# Altium schematic sheet Load.SchDoc
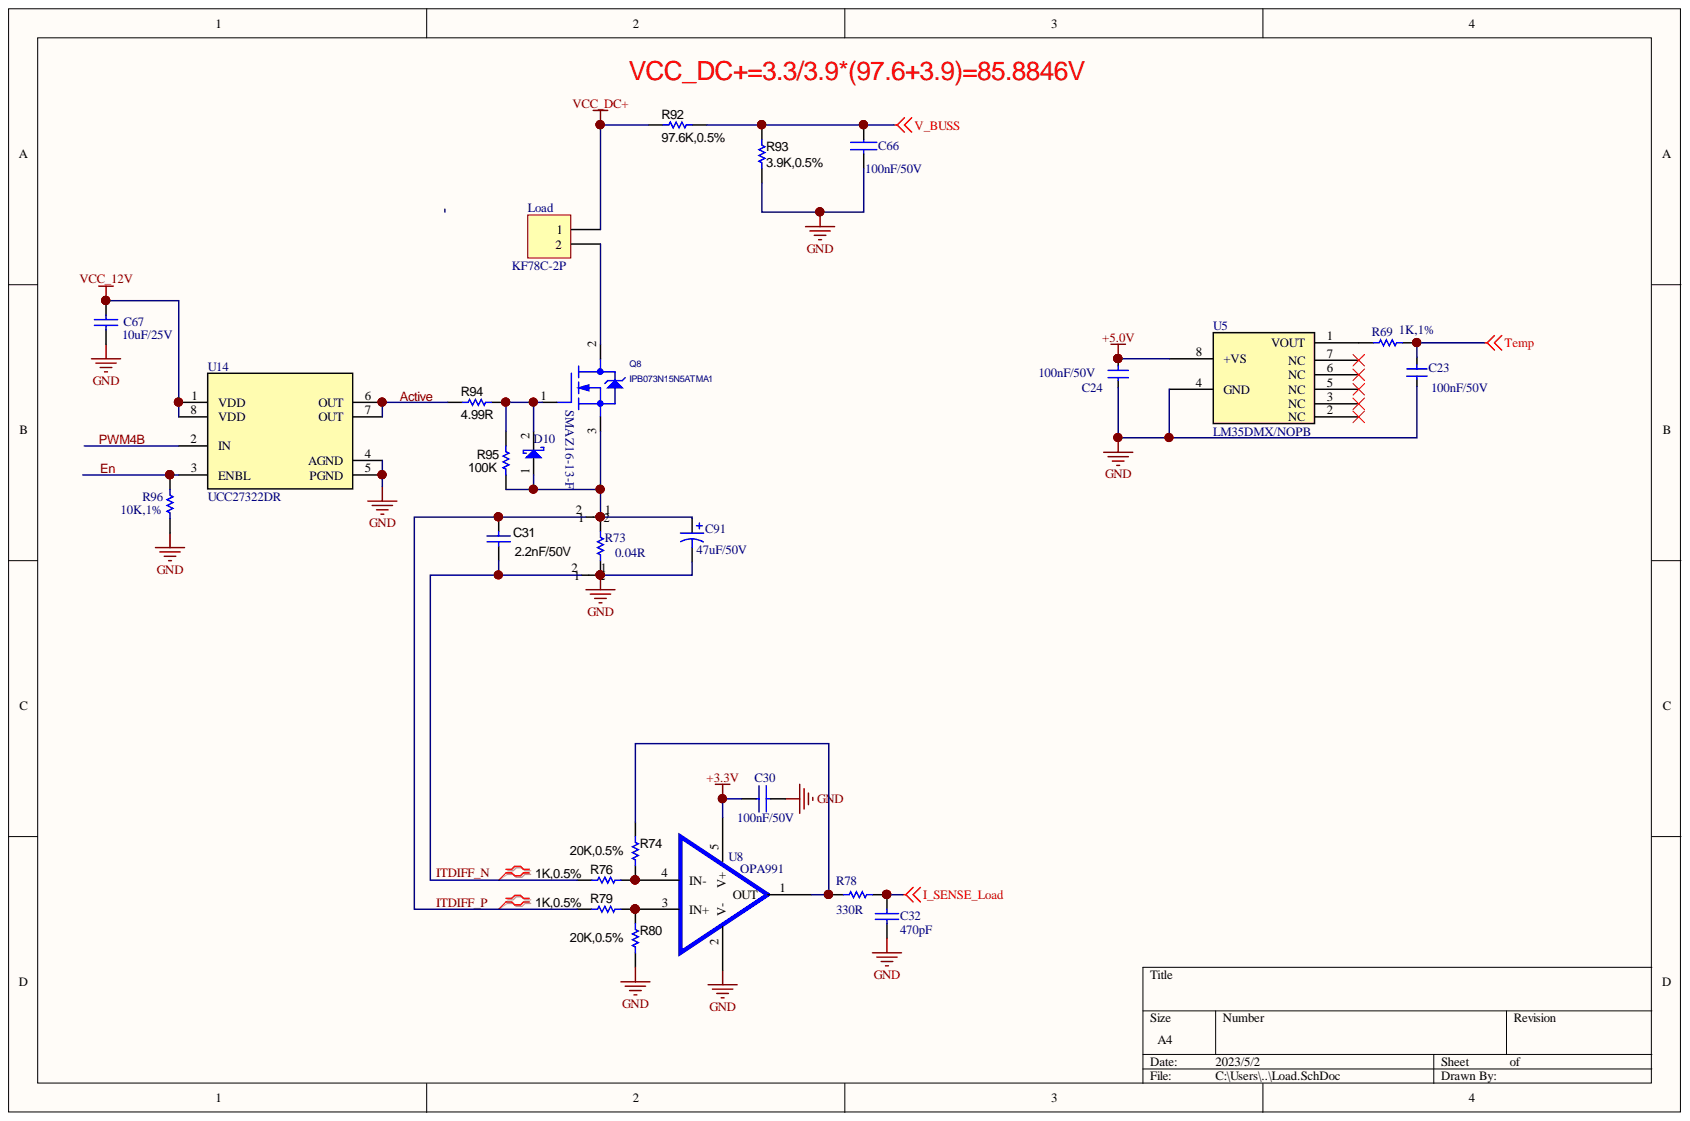
[diagram p0: Load.SchDoc]

## Parts
C23  100nF/50V  [0603]
C24  100nF/50V  [0603]
C30  100nF/50V  [0603]
C31  2.2nF/50V  [0603]
C32  470pF  [0603]
C66  100nF/50V  [0603]
C67  10uF/25V  [1206]
C91  47uF/50V  [HDR_1X2]
D10  SMAZ16-13-F  [Zener_SMA]
Load  KF78C-2P  [KF65C-2P]
NT7  NT  [diff_net_tie]  (value not drawn)
NT8  NT  [diff_net_tie]  (value not drawn)
Q8  IPB073N15N5ATMA1  [TO-263AB]
R69  1K,1%  [0603]
R73  0.04R  [4527]
R74  20K,0.5%  [0603]
R76  1K,0.5%  [0603]
R78  330R  [0603]
R79  1K,0.5%  [0603]
R80  20K,0.5%  [0603]
R92  97.6K,0.5%  [0603]
R93  3.9K,0.5%  [0603]
R94  4.99R  [0603]
R95  100K  [0603]
R96  10K,1%  [0603]
U5  LM35DMX/NOPB  [SOIC8_3.90MM]
U8  OPA991  [SOT-235]
U14  UCC27322DR  [SOIC8_3.90MM]

## Nets
+3.3V: C30.1 U8.5(V+)
+5.0V: C24.2 U5.8(+VS)
GND: C23.1 C24.1 C30.2 C32.2 C66.2 C67.1 C91.2 NT8.1 R73.2 R80.2 R93.1 R96.1 U5.4(GND) U8.2(V-) U14.4(AGND) U14.5(PGND)
I_SENSE_Load: C32.1 R78.1
Temp: C23.2 R69.1
V_BUSS: C66.1 R92.1 R93.2
VCC_12V: C67.2 U14.1(VDD) U14.8(VDD)
VCC_DC+: Load.1 R92.2
Active: R94.1 U14.6(OUT) U14.7(OUT)
En: R96.2 U14.3(ENBL)
ITDIFF_N: C31.1 NT8.2 R76.1
ITDIFF_P: C31.2 NT7.2 R79.1
PWM4B: U14.2(IN)
NetC91_1: C91.1 D10.1 NT7.1 Q8.3 R73.1 R95.1
NetD10_2: D10.2 Q8.1 R94.2 R95.2
NetLoad_2: Load.2 Q8.2
NetR69_2: R69.2 U5.1(VOUT)
NetR74_1: R74.1 R78.2 U8.1(OUT)
NetR74_2: R74.2 R76.2 U8.4(IN-)
NetR79_2: R79.2 R80.1 U8.3(IN+)
N00218:

Not connected: U5 2(NC) 3(NC) 5(NC) 6(NC) 7(NC)

## Library data of the parts
C23 C24 C67 = Cap: Component Kind: Standard
  C67: LatestRevisionDate: 17-Jul-2002; LatestRevisionNote: Re-released for DXP Platform.; Library Name: XDS100V3.SCHLIB; PackageReference: RAD-0.3; Published: 8-Jun-2000; Signal Integrity: Cap; Simulation: CAP; Publisher: Altium Limited
C30 C66 = Component-CAP: User Parameter 1: foot; Component Kind: Standard; Library Name: AltiumDesigner_Lib-tony.SchLib
C31 = GRM188R71C102KA01D: Manufacturer: MuRata; Cap_F: 0.000000001; CompType: Capacitor; Polarized: N; TaMax_C: 125; TaMin_C: -55; TempCo: X7R; Tolerance_%: 10; U/M: EA; Value: 1000pF; Value1: Unknown; Value2: 16V; VDC_V: 16; Cap_Case: 0603; Component Kind: Standard; ComponentLink1Description: Datasheet link; ComponentLink1URL: http://search.murata.co.jp/Ceramy/PnsearchViewAction.do?HnOFG=ON&HnNFG=ON&SEL_CNT=50&sLang=en&sKey=GRM188R71C102KA01D&x=9&y=9&search=startwith; LatestRevisionDate: 2014-07-08; Library Name: Capacitor_Ceramic.IntLib; MountType: SMT; PackageReference: 0603; PartNumber: GRM188R71C102KA01D; Published: 2008-06-19; RoHS: Y; Signal Integrity: Cap; Simulation: Cap; Supplier 1: Digi-Key; Supplier 2: Mouser; Supplier Part Number 1: GRM188R71C102KA01D-ND; Supplier Part Number 2: 81-GRM188R71C102KA1D; SymbolVersion: 2008-07-09; Technology: Ceramic; ValueDisplayed: 1000pF; Publisher: Texas Instruments
C32 = C1206: Component Kind: Standard; Library Name: useForA104.IntLib
C91 = Cap Pol1: Value: 10uF; Component Kind: Standard; LatestRevisionDate: 17-Jul-2002; LatestRevisionNote: Re-released for DXP Platform.; PackageReference: RB7.6-15; Published: 8-Jun-2000; Publisher: Altium Limited
D10 = Diode-Schottky: Component Kind: Standard; Library Name: AD_Lib.SchLib
Load = Header 2: Header, 2-Pin; Component Kind: Standard; LatestRevisionDate: 17-Jul-2002; LatestRevisionNote: Re-released for DXP Platform.; Library Name: Miscellaneous Connectors.IntLib; Signal Integrity: Connector; Publisher: Altium Limited
NT7 NT8 = diffpair_net_tie: Component Kind: Net Tie; Library Name: AltiumDesigner_Lib-syc.SchLib
Q8 = MOSFET_N_2: Part Number: D3-0080040; Component Height: 4.826MM; Component Kind: Standard
R69 = Res1: Value: 440; Component Kind: Standard; Published: 8-Jun-2000; Revision: July-2002: Re-released for DXP Platform.; Library Name: syc.SchLib; Publisher: Altium Limited
R73 R96 = Res1: Value: 2.2K; Component Kind: Standard; LatestRevisionDate: 17-Jul-2002; LatestRevisionNote: Re-released for DXP Platform.; Library Name: Miscellaneous Devices.IntLib; PackageReference: AXIAL-0.3; Published: 8-Jun-2000; Signal Integrity: Res; Simulation: RESISTOR; Publisher: Altium Limited
R74 R80 R93 = RG1608P-4991-B-T5: Manufacturer: Susumu Co Ltd; CompType: Resistor; EIA_Code: E192; Power_W: 0.1; Res_ohm: 4990; TempCo: 25 ppm/C; U/M: EA; Value: 4.99k; Value1: 0.1%; Value2: 0.1W; Value_Code: 4k99; VDC_V: 75; Component Kind: Standard; ComponentLink1Description: Datasheet link; ComponentLink1URL: http://www.susumu.co.jp/english/pdf/products-j01-01.pdf; LatestRevisionDate: 2014-05-20; Library Name: Resistor_0603.IntLib; MountType: SMT; PackageReference: 0603; PartNumber: RG1608P-4991-B-T5; Published: 2008-06-26; RoHS: Y; Signal Integrity: Resistor; Simulation: Resistor; Supplier 1: Digi-Key; Supplier 2: Mouser; Supplier Part Number 1: RG16P4.99KBCT-ND; Supplier Part Number 2: 754-RG1608P-4991-BT5; SymbolVersion: 2009-05-29; Technology: Metal Film; Tolerance_%: 0.1; Publisher: Texas Instruments
R76 R79 = RC0603FR-071KL: Manufacturer: Yageo America; CompType: Resistor; EIA_Code: E96; Power_W: 0.1; Res_ohm: 1000; TempCo: 200 ppm/C; Tolerance_%: 1; U/M: EA; Value: 1.00k; Value1: 1%; Value2: 0.1W; Value_Code: 1k00; VDC_V: 150; Component Kind: Standard; ComponentLink1Description: Datasheet link; ComponentLink1URL: http://www.yageo.com/pdf/Pu-RC0603_51_PbFree_L_2.pdf; LatestRevisionDate: 2014-05-20; Library Name: Resistor_0603.IntLib; MountType: SMT; PackageReference: 0603; PartNumber: RC0603FR-071KL; Published: 2008-06-26; RoHS: Y; Signal Integrity: Resistor; Simulation: Resistor; Supplier 1: Digi-Key; Supplier 2: Mouser; Supplier 3: Farnell; Supplier Part Number 1: 311-1.00KHRCT-ND; Supplier Part Number 2: 603-RC0603FR-071KL; Supplier Part Number 3: 9238484; SymbolVersion: 2009-05-29; Technology: Thick Film; Publisher: Texas Instruments
R78 = R1206: Component Kind: Standard; Library Name: useForA104.IntLib
R92 R95 = RG1608P-8662-B-T5: Manufacturer: Susumu Co Ltd; CompType: Resistor; EIA_Code: E192; Power_W: 0.1; Res_ohm: 86600; TempCo: 25 ppm/C; U/M: EA; Value: 86.6k; Value1: 0.1%; Value2: 0.1W; Value_Code: 86k6; VDC_V: 75; Component Kind: Standard; ComponentLink1Description: Datasheet link; ComponentLink1URL: http://www.susumu.co.jp/english/pdf/products-j01-01.pdf; LatestRevisionDate: 2014-05-20; Library Name: Resistor_0603.IntLib; MountType: SMT; PackageReference: 0603; PartNumber: RG1608P-8662-B-T5; Published: 2008-06-26; RoHS: Y; Signal Integrity: Resistor; Simulation: Resistor; Supplier 1: Digi-Key; Supplier 2: Mouser; Supplier Part Number 1: RG16P86.6KBCT-ND; Supplier Part Number 2: 754-RG1608P-8662-BT5; SymbolVersion: 2009-05-29; Technology: Metal Film; Tolerance_%: 0.1; Publisher: Texas Instruments
R94 = CRCW060310R0FKEA: Manufacturer: Vishay-Dale; CompType: Resistor; EIA_Code: E96; Power_W: 0.1; Res_ohm: 10; TempCo: 100 ppm/C; Tolerance_%: 1; U/M: EA; Value: 10.0; Value1: 1%; Value2: 0.1W; Value_Code: 10R0; VDC_V: 75; Component Kind: Standard; ComponentLink1Description: Datasheet link; ComponentLink1URL: http://www.vishay.com/docs/20035/dcrcwe3.pdf; LatestRevisionDate: 2014-05-20; Library Name: Resistor_0603.IntLib; MountType: SMT; PackageReference: 0603; PartNumber: CRCW060310R0FKEA; Published: 2008-06-26; RoHS: Y; Signal Integrity: Resistor; Simulation: Resistor; Supplier 1: Digi-Key; Supplier 2: Mouser; Supplier 3: Farnell; Supplier Part Number 1: 541-10.0HCT-ND; Supplier Part Number 2: 71-CRCW0603-10-E3; Supplier Part Number 3: 1469751; SymbolVersion: 2009-05-29; Technology: Thick Film; Publisher: Texas Instruments
U5 = LM35DMX/NOPB: No Description Available; Built_By: EMA_UL_Team; Vendor: Texas Instruments; Component Kind: Standard; Manufacturer_Part_Number: LM35DMX/NOPB; RefDes: RefDes; Type: DEV; Value: Value; Copyright: Copyright (C) 2018 Accelerated Designs. All rights reserved; Library Name: AltiumDesigner_Lib-syc.SchLib
U8 = TI-OPA991: Component Kind: Standard; Library Name: AltiumDesigner_Lib-syc.SchLib
U14 = TI-UCC27322DR: Mfr_Name: Texas Instruments; Component Kind: Standard; Manufacturer_Part_Number: UCC27322DR; RefDes: RefDes; Type: DEV; Copyright: Copyright (C) 2022 Ultra Librarian. All rights reserved.; Library Name: AltiumDesigner_Lib-syc.SchLib
